# Altium schematic sheet AN-DGX - USB_JTAG_UART.SchDoc("AN-DGX - USB_JTAG_UART")
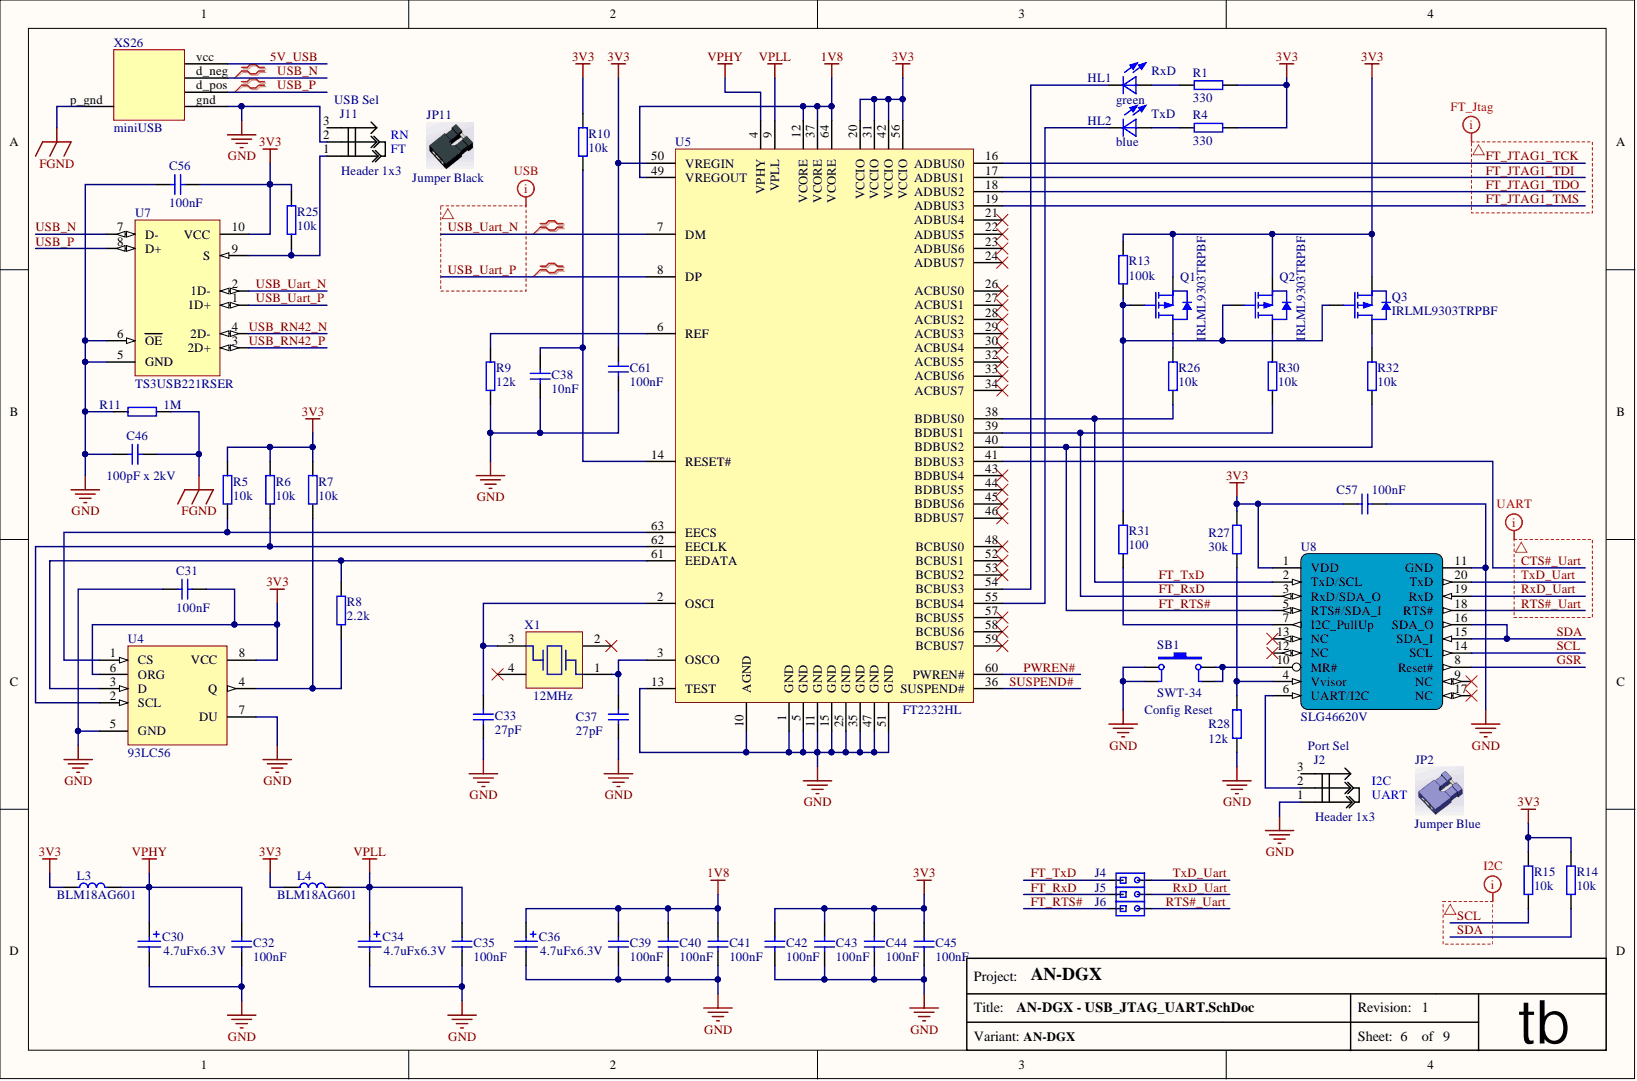
[diagram p0: AN-DGX - USB_JTAG_UART.SchDoc]

## Parts
C30  value 4.7uFx6.3V  [CAP TAN 0805 2012 SIZE R]  (hidden comment: uF)
C31  value 100nF  [C0603]  (hidden comment: CAP)
C32  value 100nF  [C0603]  (hidden comment: CAP)
C33  value 27pF  [C0603]  (hidden comment: CAP)
C34  value 4.7uFx6.3V  [CAP TAN 0805 2012 SIZE R]  (hidden comment: uF)
C35  value 100nF  [C0603]  (hidden comment: CAP)
C36  value 4.7uFx6.3V  [CAP TAN 0805 2012 SIZE R]  (hidden comment: uF)
C37  value 27pF  [C0603]  (hidden comment: CAP)
C38  value 10nF  [C0603]  (hidden comment: CAP)
C39  value 100nF  [C0603]  (hidden comment: CAP)
C40  value 100nF  [C0603]  (hidden comment: CAP)
C41  value 100nF  [C0603]  (hidden comment: CAP)
C42  value 100nF  [C0603]  (hidden comment: CAP)
C43  value 100nF  [C0603]  (hidden comment: CAP)
C44  value 100nF  [C0603]  (hidden comment: CAP)
C45  value 100nF  [C0603]  (hidden comment: CAP)
C46  value 100pF x 2kV  [C1206]  (hidden comment: CAP)
C56  value 100nF  [C0603]  (hidden comment: CAP)
C57  value 100nF  [C0603]  (hidden comment: CAP)
C61  value 100nF  [C0603]  (hidden comment: CAP)
HL1  RxD  value green  [0805_LED_green]
HL2  TxD  value blue  [0805_LED_blue]
J2  Port Sel  value Header 1x3  [Jumper_3pin]
J4  (no value)  [Jumper_smd_d3]  (no BOM)
J5  (no value)  [Jumper_smd_d3]  (no BOM)
J6  (no value)  [Jumper_smd_d3]  (no BOM)
J11  USB Sel  value Header 1x3  [Jumper_3pin_black]
JP2  value Jumper Blue  Supplier 1 Part: Jumper  (hidden comment: VCC_sel)  (mechanical)
JP11  value Jumper Black  (mechanical)
L3  value BLM18AG601  [ferrite_bead_0603]  (hidden comment: BLM18PG221SN1D)
L4  value BLM18AG601  [ferrite_bead_0603]  (hidden comment: BLM18PG221SN1D)
Q1  IRLML9303TRPBF  [SOT-23]
Q2  IRLML9303TRPBF  [SOT-23]
Q3  IRLML9303TRPBF  [SOT-23]
R1  value 330  [0603_RESISTOR]  (hidden comment: Resistor)
R4  value 330  [0603_RESISTOR]  (hidden comment: Resistor)
R5  value 10k  [0603_RESISTOR]  (hidden comment: Resistor)
R6  value 10k  [0603_RESISTOR]  (hidden comment: Resistor)
R7  value 10k  [0603_RESISTOR]  (hidden comment: Resistor)
R8  value 2.2k  [0603_RESISTOR]  (hidden comment: Resistor)
R9  value 12k  [0603_RESISTOR]  (hidden comment: Resistor)
R10  value 10k  [0603_RESISTOR]  (hidden comment: Resistor)
R11  value 1M  [0805_resistor]  (hidden comment: Resistor)
R13  value 100k  [0603_RESISTOR]  (hidden comment: Resistor)
R14  value 10k  [0603_RESISTOR]  (hidden comment: Resistor)
R15  value 10k  [0603_RESISTOR]  (hidden comment: Resistor)
R25  value 10k  [0603_RESISTOR]  (hidden comment: Resistor)
R26  value 10k  [0603_RESISTOR]  (hidden comment: Resistor)
R27  value 30k  [0603_RESISTOR]  (hidden comment: Resistor)
R28  value 12k  [0603_RESISTOR]  (hidden comment: Resistor)
R30  value 10k  [0603_RESISTOR]  (hidden comment: Resistor)
R31  value 100  [0603_RESISTOR]  (hidden comment: Resistor)
R32  value 10k  [0603_RESISTOR]  (hidden comment: Resistor)
SB1  Config Reset  value SWT-34  [Key 6.2x6.2]
U4  value 93LC56  [SOIC-8 150 Mil]  (hidden comment: 93xCxx)
U5  FT2232HL  [LQFP64]
U7  TS3USB221  value TS3USB221RSER  [UQFN10]
U8  SLG46620V  [STQFN-20]
X1  value 12MHz  [OSC_CX3225GB]  (hidden comment: OSC_CX3225GB)
XS26  value miniUSB  [MiniUSB_Conn_2types]  (hidden comment: miniUSB_conn)

## Nets
1V8: C36.1 C39.2 C40.2 C41.2 U5.12(VCORE) U5.37(VCORE) U5.49(VREGOUT) U5.64(VCORE)
3V3: C31.1 C42.2 C43.2 C44.2 C45.2 C56.1 C57.2 C61.2 L3.1 L4.1 Q1.2 Q2.2 Q3.2 R1.1 R4.1 R5.2 R6.2 R7.2 R10.2 R13.2 R14.1 R15.1 R25.2 R27.1 U4.6(ORG) U4.8(VCC) U5.20(VCCIO) U5.31(VCCIO) U5.42(VCCIO) U5.50(VREGIN) U5.56(VCCIO) U7.10(VCC) U8.1(VDD)
FGND: C46.1 R11.1 XS26.p_gnd
GND: C30.2 C31.2 C32.1 C33.1 C34.2 C35.1 C36.2 C37.1 C38.1 C39.1 C40.1 C41.1 C42.1 C43.1 C44.1 C45.1 C46.2 C56.2 C57.1 C61.1 J2.1 J11.2 R9.1 R11.2 R28.1 SB1.1 SB1.3 U4.5(GND) U4.7(DU) U5.1(GND) U5.5(GND) U5.10(AGND) U5.11(GND) U5.13(TEST) U5.15(GND) U5.25(GND) U5.35(GND) U5.47(GND) U5.51(GND) U7.5(GND) U7.6(OE) U8.11(GND) XS26.gnd
VPHY: C30.1 C32.2 L3.2 U5.4(VPHY)
VPLL: C34.1 C35.2 L4.2 U5.9(VPLL)
5V_USB: XS26.vcc
CTS#_Uart: U5.41(BDBUS3)
FT_JTAG1_TCK: U5.16(ADBUS0)
FT_JTAG1_TDI: U5.17(ADBUS1)
FT_JTAG1_TDO: U5.18(ADBUS2)
FT_JTAG1_TMS: U5.19(ADBUS3)
FT_RTS#: J6.1 R32.1 U5.40(BDBUS2) U8.5(RTS#/SDA_I)
FT_RxD: J5.1 R30.1 U5.39(BDBUS1) U8.3(RxD/SDA_O)
FT_TxD: J4.1 R26.1 U5.38(BDBUS0) U8.2(TxD/SCL)
GSR: U8.8(Reset#)
PWREN#: U5.60(PWREN#)
RTS#_Uart: J6.2 U8.18(RTS#)
RxD_Uart: J5.2 U8.19(RxD)
SCL: R15.2 U8.14(SCL)
SDA: R14.2 U8.15(SDA_I) U8.16(SDA_O)
SUSPEND#: U5.36(SUSPEND#)
TxD_Uart: J4.2 U8.20(TxD)
USB_N: U7.7(D-) XS26.d_neg
USB_P: U7.8(D+) XS26.d_pos
USB_RN42_N: U7.4(2D-)
USB_RN42_P: U7.3(2D+)
USB_Uart_N: U5.7(DM) U7.2(1D-)
USB_Uart_P: U5.8(DP) U7.1(1D+)
NetC33_2: C33.2 U5.2(OSCI) X1.3
NetC37_2: C37.2 U5.3(OSCO) X1.1
NetC38_2: C38.2 R10.1 U5.14(RESET#)
NetHL1_1: HL1.1 R1.2
NetHL1_2: HL1.2 U5.54(BCBUS3)
NetHL2_1: HL2.1 R4.2
NetHL2_2: HL2.2 U5.55(BCBUS4)
NetJ2_2: J2.2 U8.6(UART/I2C)
NetJ11_1: J11.1 R25.1 U7.9(S)
NetQ1_1: Q1.1 Q2.1 Q3.1 R13.1 R31.2
NetQ1_3: Q1.3 R26.2
NetQ2_3: Q2.3 R30.2
NetQ3_3: Q3.3 R32.2
NetR5_1: R5.1 U4.1(CS) U5.63(EECS)
NetR6_1: R6.1 U4.2(SCL) U5.62(EECLK)
NetR7_1: R7.1 R8.1 U4.4(Q)
NetR8_2: R8.2 U4.3(D) U5.61(EEDATA)
NetR9_2: R9.2 U5.6(REF)
NetR27_2: R27.2 R28.2 U8.4(Vvisor)
NetR31_1: R31.1 U8.7(I2C_PullUp)
NetSB1_2: SB1.2 SB1.4 U8.10(MR#)
N00503:
N00504:
N00505:
N00506:

Not connected: J2 3; J11 3; U5 21(ADBUS4) 22(ADBUS5) 23(ADBUS6) 24(ADBUS7) 26(ACBUS0) 27(ACBUS1) 28(ACBUS2) 29(ACBUS3) 30(ACBUS4) 32(ACBUS5) 33(ACBUS6) 34(ACBUS7) 43(BDBUS4) 44(BDBUS5) 45(BDBUS6) 46(BDBUS7) 48(BCBUS0) 52(BCBUS1) 53(BCBUS2) 57(BCBUS5) 58(BCBUS6) 59(BCBUS7); U8 9(NC) 12(NC) 13(NC) 17(NC); X1 2 4

## Library data of the parts
C30 C34 C36 = Cap_pol_tant: Manufacturer Part: TEESVP1A475M8R; Manufacturer: NEC; Type of Component: Tantalum Capacitor; Supplier 1 Part: 0805 4.7uF 6.3V; Supplier 1 Link: aliexpress.com/item/4-7UF-10V-P-type-0805-2012-SMD-Tantalum-Capacitor-TEESVP1A475M8R-x100PCS-FREE-SHIPPING/2021782850.html?spm=2114.01010208.3.2.okythJ&ws_ab_test=searchweb0_0,searchweb201602_1_10065_10068_10501_10503_10000032_119_10000025_10000029_430_10000028_10060_10062_10056_10055_10000062_10054_10059_10099_10000022_10000012_10103_10000015_10102_10096_10000018_10000019_10000056_10000059_10052_10053_10107_10050_10106_10051_10000053_10000007_10000050_10084_10118_10083_10000047_10080_10082_10081_10110_10111_10112_10113_10114_10115_10000041_10000044_10078_10079_10000038_429_10073_10000035_10121-119_10503_10501,searchweb201603_1,afswitch_1,single_sort_1_default&btsid=1ad9c6e0-95d3-4588-aff6-9bdc2e468ca5; Supplier 1: aliexpress.com; Package / Case: 0805; Component Kind: Standard; Library Name: RPI-VITA.IntLib
C31 C32 C35 C39-C45 C56 C57 C61 = CAP: Manufacturer Part: VJ0603Y104JXJPW1BC; Manufacturer: Vishay / Vitramon; Type of Component: Ceramic Capacitor; Supplier 3 Part: 001832; Supplier 3 Link: http://voron.ua/catalog/001832; Supplier 3: http://voron.ua; Supplier 2 Part: 182925; Supplier 2 Link: http://imrad.com.ua/ru/cc0603krx7r8bb104; Supplier 2: http://imrad.com.ua/; Supplier 1 Link: aliexpress.com/item/100pcs-lot-100nF-X7R-Error-10-50V-0603-smd-capacitor/32318089455.html?spm=2114.03010208.3.2.PDNk2A&ws_ab_test=searchweb201556_8,searchweb201602_1_10065_10068_112_10069_110_111_418_10017_109_108_10060_10061_10062_10057_10039_10056_10055_10054_10059_10058_10073_10070_10052_10053_10050_10051,searchweb201603_1&btsid=c39062ca-3db8-4562-8db0-c782461646c7; Supplier 1: aliexpress.com; Package / Case: 0603; Manufacturer Link: http://www.vishay.com/product?docid=28548; Component Kind: Standard; Library Name: RPI-VITA.IntLib
C33 C37 = CAP: Manufacturer Part: VJ0603A270GXAPW1BC; Manufacturer: Vishay / Vitramon; Type of Component: Ceramic Capacitor; Supplier 2 Part: 183055; Supplier 2 Link: http://imrad.com.ua/ru/cc0603jrnpo9bn270; Supplier 2: http://imrad.com.ua/; Supplier 1 Part: 0603 27pF; Supplier 1 Link: aliexpress.com/item/1LOT-100PCS-Chip-Capacitors-27pF-270J-50V-5-0603-Package0603-1608-Metric/32561911564.html?spm=2114.01010208.3.12.XP8dkk&ws_ab_test=searchweb0_0,searchweb201602_1_10065_10068_10501_10503_10000032_119_10000025_10000029_430_10000028_10060_10062_10056_10055_10000062_10054_10059_10099_10000022_10000012_10103_10000015_10102_10096_10000018_10000019_10000056_10000059_10052_10053_10107_10050_10106_10051_10000053_10000007_10000050_10084_10118_10083_10000047_10080_10082_10081_10110_10111_10112_10113_10114_10115_10000041_10000044_10078_10079_10000038_429_10073_10000035_10121-10503_10501,searchweb201603_1,afswitch_1,single_sort_1_default&btsid=7449e9ee-25f8-407e-b73f-e18288cc212b; Supplier 1: aliexpress.com; Package / Case: 0603; Manufacturer Link: http://www.vishay.com/product?docid=28548; Component Kind: Standard; Library Name: RPI-VITA.IntLib
C38 = CAP: Manufacturer Part: VJ0603Y103KXACW1BC; Manufacturer: Vishay / Vitramon; Type of Component: Ceramic Capacitor; Supplier 3 Part: 001833; Supplier 3 Link: http://voron.ua/catalog/001833; Supplier 3: http://voron.ua/; Supplier 2 Part: 176847; Supplier 2 Link: http://imrad.com.ua/ru/cercap-0-01-50v-0603-kx7r-product-99103; Supplier 2: http://imrad.com.ua/; Supplier 1 Part: 0603 10nF; Supplier 1 Link: aliexpress.com/item/Free-Shopping-100PCS-0603-10NF-103-0-01uF-50V-10-50v-X7R-Ceramic-capacitors-Good-Quality/1090724777.html?spm=2114.01010208.3.22.dy0dqC&ws_ab_test=searchweb0_0,searchweb201602_1_10065_10068_10501_10503_10000032_119_10000025_10000029_430_10000028_10060_10062_10056_10055_10000062_10054_10059_10099_10000022_10000012_10103_10000015_10102_10096_10000018_10000019_10000056_10000059_10052_10053_10107_10050_10106_10051_10000053_10000007_10000050_10084_10118_10083_10000047_10080_10082_10081_10110_10111_10112_10113_10114_10115_10000041_10000044_10078_10079_10000038_429_10073_10000035_10121-10503_10501,searchweb201603_1,afswitch_1,single_sort_1_default&btsid=8193d816-c2c6-46a7-a3e7-3206b4f88985; Supplier 1: aliexpress.com; Package / Case: 0603; Manufacturer Link: http://www.vishay.com/product?docid=28548; Component Kind: Standard; Library Name: RPI-VITA.IntLib
C46 = CAP: Type of Component: Ceramic Capacitor; Supplier 3 Part: 019223; Supplier 3 Link: http://voron.ua/catalog/019223; Supplier 3: http://voron.ua/; Supplier 2 Part: 198353; Supplier 2 Link: http://imrad.com.ua/ru/cc1206jknpodbn101; Supplier 2: http://imrad.com.ua/; Supplier 1 Part: 1206 100pF 2kV; Supplier 1 Link: aliexpress.com/item/1LOT-50PCS-Chip-Capacitors-100pF-101J-2000V-2kV-5-1206-Package1206-3216-Metric/32561851945.html?spm=2114.03010208.3.118.3xNC69&ws_ab_test=searchweb0_0,searchweb201602_1_10065_10068_10501_10503_10000032_119_10000025_10000029_430_10000028_10060_10062_10056_10055_10000062_10054_10059_10099_10000022_10000012_10103_10000015_10102_10096_10000018_10000019_10000056_10000059_10052_10053_10107_10050_10106_10051_10000053_10000007_10000050_10117_10084_10083_10000047_10080_10082_10081_10110_10111_10112_10113_10114_10115_10000041_10000044_10078_10079_10000038_429_10073_10000035_10121-10503_10501,searchweb201603_1,afswitch_1,single_sort_1_default&btsid=08511054-7293-4023-a14a-e6e313e7b3b7; Supplier 1: aliexpress.com; Package / Case: 1206; Component Kind: Standard; Library Name: RPI-VITA.IntLib
HL1 = LED: Manufacturer Part: 0805 Led Green; Type of Component: Led; Supplier 3 Part: 019519; Supplier 3 Link: http://voron.ua/catalog/019519; Supplier 3: http://voron.ua/; Supplier 2 Part: 166642; Supplier 2 Link: http://imrad.com.ua/ru/fyls-0805gc; Supplier 2: http://imrad.com.ua/; Supplier 1 Part: 0805 Led Green; Supplier 1 Link: aliexpress.com/store/product/FREE-SHIPPING-1000PCS-0805-2012-Green-Clear-Ultra-Bright-SMD-LED-Indication/102034_32595423201.html?storeId=102034&spm=2114.12010208.100007.36.H0BBkE; Supplier 1: aliexpress.com; Package / Case: 0805; Component Kind: Standard; Library Name: RPI-VITA.IntLib
HL2 = LED: Manufacturer Part: 0805 Led Blue; Type of Component: Led; Supplier 3 Part: 016316; Supplier 3 Link: http://voron.ua/catalog/016316; Supplier 3: http://voron.ua/; Supplier 2 Part: 100043; Supplier 2 Link: http://imrad.com.ua/ru/fyls-0805ubc-pbf; Supplier 2: http://imrad.com.ua/; Supplier 1 Part: 0805 Led Blue; Supplier 1 Link: aliexpress.com/store/product/FREE-SHIPPING-1000PCS-0805-2012-Blue-Clear-Ultra-Bright-SMD-LED-Indication/102034_32593006615.html?storeId=102034&spm=2114.12010208.100007.33.hkzVBq; Supplier 1: aliexpress.com; Package / Case: 0805; Component Kind: Standard; Library Name: RPI-VITA.IntLib
J2 J11 = jumper_3pin: Type of Component: Header for jumper 3 pin; Supplier 3 Part: 000631; Supplier 3 Link: http://voron.ua/catalog/000631; Supplier 3: http://voron.ua; Supplier 2 Part: 202826; Supplier 2 Link: http://imrad.com.ua/ru/pls-40-product-122266; Supplier 2: http://imrad.com.ua; Supplier 1 Link: aliexpress.com/item/1Set-20PCS-40Pin-2-54mm-Single-Row-Straight-Male-Pin-Header-Connector-Strip-For-PBC-Ardunio/32329088739.html?spm=2114.03010208.3.2.0ijeiM&ws_ab_test=searchweb201556_1,searchweb201644_1_505_506_503_504_502_10001_10002_10017_10010_10005_10011_10006_10003_10004_10009_10007_10008,searchweb201560_5,searchweb1451318400_-1,searchweb1451318411_6452&btsid=d8fe2734-cbe4-429c-a307-e5400297e31b; Supplier 1: aliexpress.com; Package / Case: Header 1 x 3; Component Kind: Standard; Library Name: RPI-VITA.IntLib
J4-J6 = jumper_2pin_pads: Library Name: RPI-VITA.IntLib
JP2 = jumper blue: Manufacturer Part: Jumper Cap Shunts Short Circuit Cap; Manufacturer: Great Electric; Type of Component: Jumper Blue; Supplier 1 Link: http://www.aliexpress.com/item/500pcs-lot-Asssorted-Color-2-54mm-Standard-Circuit-Board-Jumper-Cap-Shunts-Short-Circuit-Cap-CGKCH119/32344305619.html?spm=2114.03010208.3.80.nPPmoy&ws_ab_test=searchweb201556_1,searchweb201644_1_505_506_503_504_502_10001_10002_10017_10010_10005_10011_10006_10003_10004_10009_10007_10008,searchweb201560_5,searchweb1451318400_-1,searchweb1451318411_6452&btsid=16d11777-edde-4755-b8b5-9adacb495b09; Supplier 1: aliexpress.com; Package / Case: 2.54mm; Library Name: RPI-VITA.IntLib
JP11 = jumper black: Manufacturer Part: Jumper Cap Shunts Short Circuit Cap; Manufacturer: Great Electric; Type of Component: Jumper Black; Supplier 1 Part: Jumper Black; Supplier 1 Link: http://www.aliexpress.com/item/500pcs-lot-Asssorted-Color-2-54mm-Standard-Circuit-Board-Jumper-Cap-Shunts-Short-Circuit-Cap-CGKCH119/32344305619.html?spm=2114.03010208.3.80.nPPmoy&ws_ab_test=searchweb201556_1,searchweb201644_1_505_506_503_504_502_10001_10002_10017_10010_10005_10011_10006_10003_10004_10009_10007_10008,searchweb201560_5,searchweb1451318400_-1,searchweb1451318411_6452&btsid=16d11777-edde-4755-b8b5-9adacb495b09; Supplier 1: aliexpress.com; Package / Case: 2.54mm; Library Name: RPI-VITA.IntLib
L3 L4 = BLM18PG221SN1D: ferrite bead 0603; Manufacturer Part: BLM18AG601SN1D; Manufacturer: Murata; Type of Component: EMI Filter Beads; Supplier 2 Part: 155530; Supplier 2 Link: http://imrad.com.ua/ru/blm18ag601sn1d; Supplier 2: http://imrad.com.ua/; Package / Case: 0603; Manufacturer Link: http://search.murata.co.jp/Ceramy/CatalogAction.do?sHinnm=BLM11A601S&sNHinnm=BLM18AG601SN1&sNhin_key=BLM18AG601SN1D&sLang=en&sParam=BLM18AG601SN1D; Component Kind: Standard; Library Name: RPI-VITA.IntLib
Q1-Q3 = p-channel MOSFET: Manufacturer: International Rectifier; Type of Component: Transistor; Value: IRLML9303TRPBF; Supplier 3 Part: 024279; Supplier 3 Link: http://voron.ua/catalog/024279; Supplier 3: http://voron.ua; Supplier 2 Part: 165010; Supplier 2 Link: http://imrad.com.ua/ru/irlml9303trpbf; Supplier 2: http://imrad.com.ua; Package / Case: SOT-23; Manufacturer Part: IRLML9303TRPBF; Description: p-channel MOSFET; Component Kind: Standard; Library Name: RPI-VITA.IntLib
R1 R4 = Resistor: Manufacturer Part: 0603 330; Manufacturer: UniOhm; Type of Component: Resistor; Supplier 2 Part: 181872; Supplier 2 Link: http://imrad.com.ua/ru/res-0603-330r-1; Supplier 2: http://imrad.com.ua/; Supplier 1 Part: 0603 330; Supplier 1 Link: aliexpress.com/store/product/0603-SMD-Resistor-1-330-ohm-5000pcs-lot/506373_32307375865.html?detailNewVersion=&categoryId=400503&spm=2114.12010208.1000023.227.iOPgvR; Supplier 1: aliexpress.com; Package / Case: 0603; Component Kind: Standard; Library Name: RPI-VITA.IntLib
R5-R7 R10 R14 R15 R25 R26 R30 R32 = Resistor: Manufacturer Part: CRCW060310K0FKEA; Manufacturer: Vishay / Dale; Type of Component: Resistor; Supplier 3 Part: (0603) 10 kom; Supplier 3 Link: http://www.kosmodrom.com.ua/prodlist.php?page=0&name=%280603%29+10+kom&okbutton=%CF%EE%E8%F1%EA+%EF%EE+%F1%EA%EB%E0%E4%F3; Supplier 3: http://www.kosmodrom.com.ua; Supplier 2 Part: 183440; Supplier 2 Link: http://imrad.com.ua/ru/rc0603jr-0710kl; Supplier 2: http://imrad.com.ua/; Supplier 1 Part: 0603 10k; Supplier 1 Link: aliexpress.com/store/product/0603-SMD-Resistor-5-10K-ohm-5000pcs-lot/506373_32307351301.html?storeId=506373&spm=2114.12010208.100007.103.XAQnpm; Supplier 1: aliexpress.com; Package / Case: 0603; Manufacturer Link: http://www.vishay.com/product?docid=20035; Component Kind: Standard; Library Name: RPI-VITA.IntLib
R8 = Resistor: Manufacturer Part: CRCW06032K20JNEA; Manufacturer: Vishay / Dale; Type of Component: Resistor; Supplier 3 Part: 023266; Supplier 3 Link: http://voron.ua/catalog/023266; Supplier 3: http://voron.ua/; Supplier 2 Part: 147328; Supplier 2 Link: http://imrad.com.ua/ru/res-0603-2k2-5-product-70186; Supplier 2: http://imrad.com.ua/; Supplier 1 Part: 0603 2.2k; Supplier 1 Link: aliexpress.com/store/product/0603-SMD-Resistor-5-2-2K-ohm-5000pcs-lot/506373_32308080995.html?spm=2114.12010108.1000023.109.DtVsO1; Supplier 1: aliexpress.com; Package / Case: 0603; Manufacturer Link: http://www.vishay.com/product?docid=20035; Component Kind: Standard; Library Name: RPI-VITA.IntLib
R9 R28 = Resistor: Manufacturer Part: CRCW060312K0FKEA; Manufacturer: Vishay / Dale; Type of Component: Resistor; Supplier 3 Part: 023603; Supplier 3 Link: http://voron.ua/catalog/023603; Supplier 3: http://voron.ua/; Supplier 2 Part: 194449; Supplier 2 Link: http://imrad.com.ua/ru/rc0603jr-0712kl; Supplier 2: http://imrad.com.ua/; Supplier 1 Part: 0603 12k; Supplier 1 Link: aliexpress.com/item/Free-Shipping-100PCS-0603-12K-12K-OHM-5-smd-resistor/1871918358.html?spm=2114.01010208.3.43.AuqUxr&ws_ab_test=searchweb0_0,searchweb201602_1_10065_10068_10501_10503_10000032_119_10000025_10000029_430_10000028_10060_10062_10056_10055_10000062_10054_10059_10099_10000022_10000012_10103_10000015_10102_10096_10000018_10000019_10000056_10000059_10052_10053_10107_10050_10106_10051_10000053_10000007_10000050_10084_10118_10083_10000047_10080_10082_10081_10110_10111_10112_10113_10114_10115_10000041_10000044_10078_10079_10000038_429_10073_10000035_10121-10503_10501,searchweb201603_1,afswitch_1,single_sort_1_default&btsid=5caa854b-9869-4055-9d65-88f7445dd534; Supplier 1: aliexpress.com; Package / Case: 0603; Manufacturer Link: http://www.vishay.com/product?docid=20035; Component Kind: Standard; Library Name: RPI-VITA.IntLib
R11 = Resistor: Manufacturer Part: CRCW08051M00FKEA; Manufacturer: Vishay / Dale; Type of Component: Resistor; Supplier 3 Part: 002143; Supplier 3 Link: http://voron.ua/catalog/002143; Supplier 3: http://voron.ua/; Supplier 2 Part: 182706; Supplier 2 Link: http://imrad.com.ua/ru/rc0805fr-071ml; Supplier 2: http://imrad.com.ua/; Supplier 1 Part: 0805 1M; Supplier 1 Link: aliexpress.com/item/SMD-Resistance-0805-1M-1-1-8W-Chip-Resistors-100PCS-LOT/32522127065.html?spm=2114.03010208.3.41.Wyav5y&ws_ab_test=searchweb0_0,searchweb201602_1_10065_10068_10501_10503_10000032_119_10000025_10000029_430_10000028_10060_10062_10056_10055_10000062_10054_10059_10099_10000022_10000012_10103_10000015_10102_10096_10000018_10000019_10000056_10000059_10052_10053_10107_10050_10106_10051_10000053_10000007_10000050_10117_10084_10083_10000047_10080_10082_10081_10110_10111_10112_10113_10114_10115_10000041_10000044_10078_10079_10000038_429_10073_10000035_10121-10503_10501,searchweb201603_1,afswitch_1,single_sort_1_default&btsid=f6a42976-96cd-4274-b20d-158de608f2dc; Supplier 1: aliexpress.com; Package / Case: 0805; Manufacturer Link: http://www.vishay.com/product?docid=20035; Component Kind: Standard; Library Name: RPI-VITA.IntLib
SB1 = SB_Tactile: Tactile Switches; Type of Component: Tact Switch; Supplier 3 Part: 017636; Supplier 3 Link: http://voron.ua/catalog/017636; Supplier 3: http://voron.ua/; Supplier 2 Part: 41517; Supplier 2 Link: http://imrad.com.ua/ru/swt-34-h5mm; Supplier 2: http://imrad.com.ua/; Supplier 1 Link: aliexpress.com/item/50-Pcs-Momentary-Tact-Tactile-Switch-SMD-SMT-PCB-4-Pin-6-x-6-x/32606731512.html?spm=2114.03010208.3.152.FgYqgH&ws_ab_test=searchweb0_0,searchweb201602_1_10065_10068_10501_10503_10000032_119_10000025_10000029_430_10000028_10060_10062_10056_10055_10000062_10054_10059_10099_10000022_10000012_10103_10000015_10102_10096_10000018_10000019_10000056_10000059_10052_10053_10107_10050_10106_10051_10000053_10000007_10000050_10117_10084_10083_10118_10000047_10080_10082_10081_10110_10111_10112_10113_10114_10115_10000041_10000044_10078_10079_10000038_429_10073_10000035_10121-10503_10501,searchweb201603_1,afswitch_1,single_sort_1_default&btsid=da13b4f2-05a9-443d-b1b0-b3b976e778d9; Supplier 1: aliexpress.com; Package / Case: 6.2 x 6.2 x 5; Component Kind: Standard; Library Name: RPI-VITA.IntLib
U4 = 93xCxx: eeprom; Manufacturer Part: 93LC56B-I/SN; Manufacturer: Microchip Technology; Type of Component: EEPROM; Supplier 2 Part: 145686; Supplier 2 Link: http://imrad.com.ua/ru/93lc56b-i-sn; Supplier 2: http://imrad.com.ua/; Supplier 1 Part: 93LC56B-I/SN; Supplier 1 Link: aliexpress.com/item/93LC56B-I-SN-93LC56BT-I-SN-93LC56BI-93LC56B-SOP8/32775841396.html?spm=2114.01010208.3.2.d8tY6w&ws_ab_test=searchweb0_0,searchweb201602_1_10065_10068_10501_10503_10000032_119_10000025_10000029_430_10000028_10060_10062_10056_10055_10000062_10054_10059_10099_10000022_10000012_10103_10000015_10102_10096_10000018_10000019_10000056_10000059_10052_10053_10107_10050_10106_10051_10000053_10000007_10000050_10084_10118_10083_10000047_10080_10082_10081_10110_10111_10112_10113_10114_10115_10000041_10000044_10078_10079_10000038_429_10073_10000035_10121-10503_10501,searchweb201603_1,afswitch_1,single_sort_1_default&btsid=ce167b10-cf56-4f40-b041-319f5144c1ab; Supplier 1: aliexpress.com; Package / Case: SOIC-8; Manufacturer Link: http://www.microchip.com/wwwproducts/Devices.aspx?product=93LC56C; Component Kind: Standard; Library Name: RPI-VITA.IntLib
U5 = FT2232HL: Manufacturer: FTDI; Type of Component: USB Interface IC; Value: FT2232HL; Supplier 2 Part: 155919; Supplier 2 Link: http://imrad.com.ua/ru/ft2232hl-r; Supplier 2: http://imrad.com.ua/; Supplier 1 Part: FT2232HL; Supplier 1 Link: aliexpress.com/item/FT2232HL-FT2232-FTDI-LQFP-64-IC-10pcs-lot-Free-shipping/32371977037.html?spm=2114.03010208.3.20.fTeT2B&ws_ab_test=searchweb0_0,searchweb201602_1_10065_10068_10501_10503_10000032_119_10000025_10000029_430_10000028_10060_10062_10056_10055_10000062_10054_10059_10099_10000022_10000012_10103_10000015_10102_10096_10000018_10000019_10000056_10000059_10052_10053_10107_10050_10106_10051_10000053_10000007_10000050_10117_10084_10083_10000047_10080_10082_10081_10110_10111_10112_10113_10114_10115_10000041_10000044_10078_10079_10000038_429_10073_10000035_10121-10503_10501,searchweb201603_1,afswitch_1,single_sort_1_default&btsid=652c4dd5-d57b-4a04-907e-91a25d69b377; Supplier 1: aliexpress.com; Package / Case: LQFP-64; Manufacturer Part: FT2232HL; Manufacturer Link: http://www.ftdichip.com/Products/ICs/FT2232H.htm; Component Kind: Standard; Library Name: RPI-VITA.IntLib
U7 = TS3USB221: TS3USB221 High-Speed USB 2.0 (480-Mbps) 1:2 Multiplexer – Demultiplexer Switch With Single Enable; Manufacturer: TI; Type of Component: USB Switch; Supplier 1 Part: TS3USB221RSER; Supplier 1 Link: aliexpress.com/item/Free-Shipping-20PCS-lot-TS3USB221RSE-TS3USB221R-TS3USB221-221R-IC-USB-SWITCH-DUAL-1X2-10UQFN/32775986435.html?spm=2114.03010208.3.2.T1uBeA&ws_ab_test=searchweb0_0,searchweb201602_1_10065_10068_10136_10137_10138_10060_10062_10141_10056_10055_10054_121_10059_10099_10103_10102_10096_10148_10052_10053_10050_10107_10142_10051_10143_10084_10117_10083_10119_10080_10082_10081_10110_10111_10112_10113_10114_10078_10079_10073_10070_10123_10120_10127_10124_10125-10120,searchweb201603_1,afswitch_1_afChannel,ppcSwitch_5,single_sort_0_default&btsid=586ac713-5112-490d-a7df-32a6c4cf3a1e&algo_expid=4c9927c9-790a-483f-8917-8b9ff43edbfc-0&algo_pvid=4c9927c9-790a-483f-8917-8b9ff43edbfc; Supplier 1: aliexpress.com; Package / Case: UQFN10; Manufacturer Part: TS3USB221RSER; Manufacturer Link: http://www.ti.com/product/TS3USB221?keyMatch=TS3USB221RSER&tisearch=Search-EN-Everything; Component Kind: Standard; Library Name: RPI-VITA.IntLib
U8 = SLG46620: GreenPAK Programmable Mixed Signal Array; Manufacturer: Silego; Type of Component: GPAK-4; Value: SLG46620V; Supplier 1 Part: SLG46620V; Supplier 1 Link: http://www.silego.com/buy/index.php?main_page=product_info&cPath=67&products_id=379; Supplier 1: silego.com; Package / Case: STQFN-20; Manufacturer Part: SLG46620V; Manufacturer Link: http://www.silego.com/products/greenpak4.html; Component Kind: Standard; Library Name: RPI-VITA.IntLib
X1 = OSC_CX3225GB: Manufacturer Part: CX3225GB12000P0HPQCC; Manufacturer: Kyocera; Type of Component: Crystal Quartz; Supplier 2 Part: 174081; Supplier 2 Link: http://imrad.com.ua/ru/kx-7t-12-0-mhz; Supplier 2: http://imrad.com.ua/; Supplier 1 Link: aliexpress.com/item/Free-shiiping-10pcs-12MHz-20ppm-20pF-3225-smd-quartz-resonator-Crystal-oscillator/32326262359.html?spm=2114.01010208.3.27.qvYCKN&ws_ab_test=searchweb0_0,searchweb201602_1_10065_10068_10501_10503_10000032_119_10000025_10000029_430_10000028_10060_10062_10056_10055_10000062_10054_10059_10099_10000022_10000012_10103_10000015_10102_10096_10000018_10000019_10000056_10000059_10052_10053_10107_10050_10106_10051_10000053_10000007_10000050_10084_10118_10083_10000047_10080_10082_10081_10110_10111_10112_10113_10114_10115_10000041_10000044_10078_10079_10000038_429_10073_10000035_10121-10503_10501,searchweb201603_1,afswitch_1,single_sort_1_default&btsid=59fb8a07-753f-4ab6-a536-288ecc6c15ce; Supplier 1: aliexpress.com; Package / Case: 3.2 mm x 2.5 mm; Manufacturer Link: http://www.kyocera-crystal.jp/eng/prdct/list/xtal/; Component Kind: Standard; Library Name: RPI-VITA.IntLib
XS26 = miniUSB_conn: mini USB Connector; Type of Component: Connector miniUSB; Supplier 3 Part: 015184; Supplier 3 Link: http://voron.ua/catalog/015184; Supplier 3: http://voron.ua/; Supplier 2 Part: 214205; Supplier 2 Link: http://imrad.com.ua/ru/razem-usb-mini-05-bf-smd; Supplier 2: http://imrad.com.ua/; Supplier 1 Part: miniUSB 5 pin; Supplier 1 Link: aliexpress.com/item/Free-shipping-200pcs-5pin-SMD-miniUSB-socket-female-SMD-mini-USB-plug-seat-connector-good-quality/731258060.html?spm=2114.01010208.3.1.V77KhM&ws_ab_test=searchweb201556_8%2Csearchweb201602_2_10017_10021_507_10022_10020_10009_9911_10008_10018_10019_101%2Csearchweb201603_1&btsid=9d837896-e768-4de2-adfc-8f6e52cb39fb; Supplier 1: aliexpress.com; Package / Case: miniUSB 5 pin; Component Kind: Standard; Library Name: RPI-VITA.IntLib
R13 R27 R31 = Resistor: Type of Component: Resistor; Supplier 1: aliexpress.com; Package / Case: 0603; Component Kind: Standard; Library Name: RPI-VITA.IntLib
  R13: Supplier 3 Part: 002199; Supplier 3 Link: http://voron.ua/catalog/002199; Supplier 3: http://voron.ua; Supplier 2 Part: 182514; Supplier 2 Link: http://imrad.com.ua/ru/rc0603fr-07100kl; Supplier 2: http://imrad.com.ua/; Supplier 1 Part: 0603 100k; Supplier 1 Link: aliexpress.com/store/product/0603-SMD-Resistor-5-100K-ohm-5000pcs-lot/506373_32307371012.html?detailNewVersion=&categoryId=400503&spm=2114.12010208.1000023.149.s8YZls
  R27: Supplier 2 Part: http://imrad.com.ua/ru/rc0603fr-0730kl; Supplier 2 Link: http://imrad.com.ua; Supplier 2: http://imrad.com.ua; Supplier 1 Part: 0603 33; Supplier 1 Link: aliexpress.com/store/product/0603-SMD-Resistor-5-30K-ohm-5000pcs-lot/506373_32307002787.html?detailNewVersion=&categoryId=400503&spm=2114.12010208.1000023.136.sR3Wdj
  R31: Supplier 3 Part: 002203; Supplier 3 Link: https://voron.ua/catalog/002203; Supplier 3: https://voron.ua; Supplier 2 Part: 183861; Supplier 2 Link: http://imrad.com.ua/ru/rc0603jr-07100rl; Supplier 2: http://imrad.com.ua/; Supplier 1 Part: 0603 100; Supplier 1 Link: aliexpress.com/item/Chip-Resistors-0603-100-ohm-100R-5-SMD-Resistors-1-10W-500PCS-LOT/32237171958.html?spm=2114.03010208.3.11.eSfZeZ&ws_ab_test=searchweb0_0,searchweb201602_1_10065_10068_10136_10137_10138_10060_10062_10141_10056_10055_10054_121_10059_10099_10103_10102_10096_10148_10052_10053_10050_10107_10142_10051_10143_10084_10117_10083_10080_10082_10081_10110_10111_10112_10113_10114_10078_10079_10073_10070_10123_10127_10124_10125,searchweb201603_1,afswitch_1,ppcSwitch_5,single_sort_0_default&btsid=2ef3d2cf-1094-4a7e-b119-24d4a6526db6&algo_expid=d54aeff9-eed1-4fee-8995-d2f86f3a7ed2-1&algo_pvid=d54aeff9-eed1-4fee-8995-d2f86f3a7ed2
